# Altium schematic sheet sch1.SchDoc
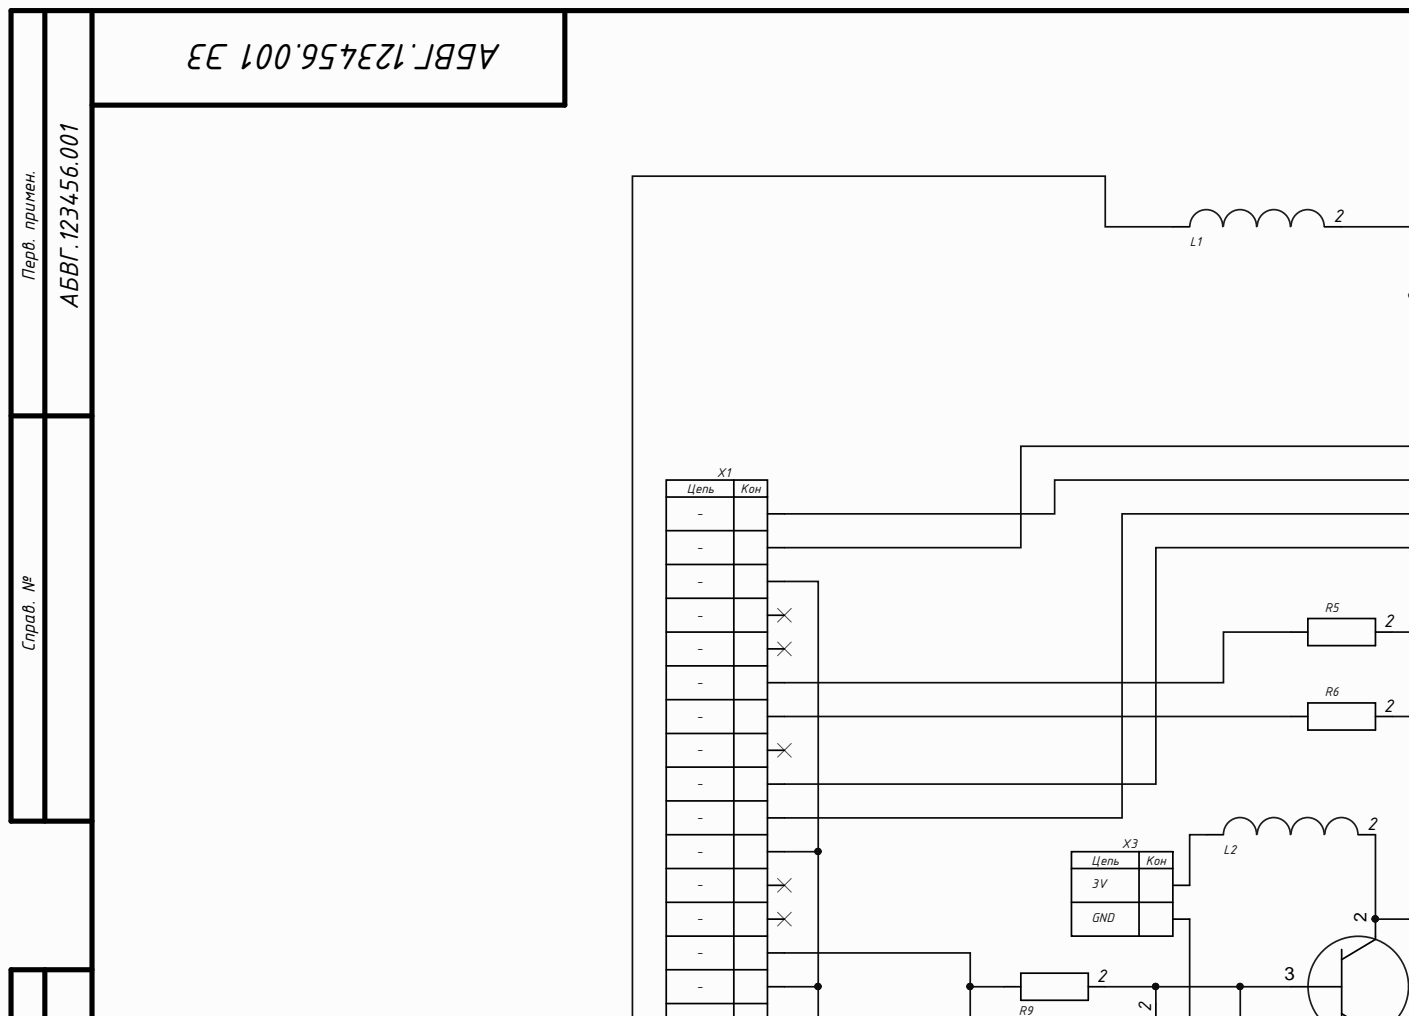
[diagram p0: sch1.SchDoc, top left]
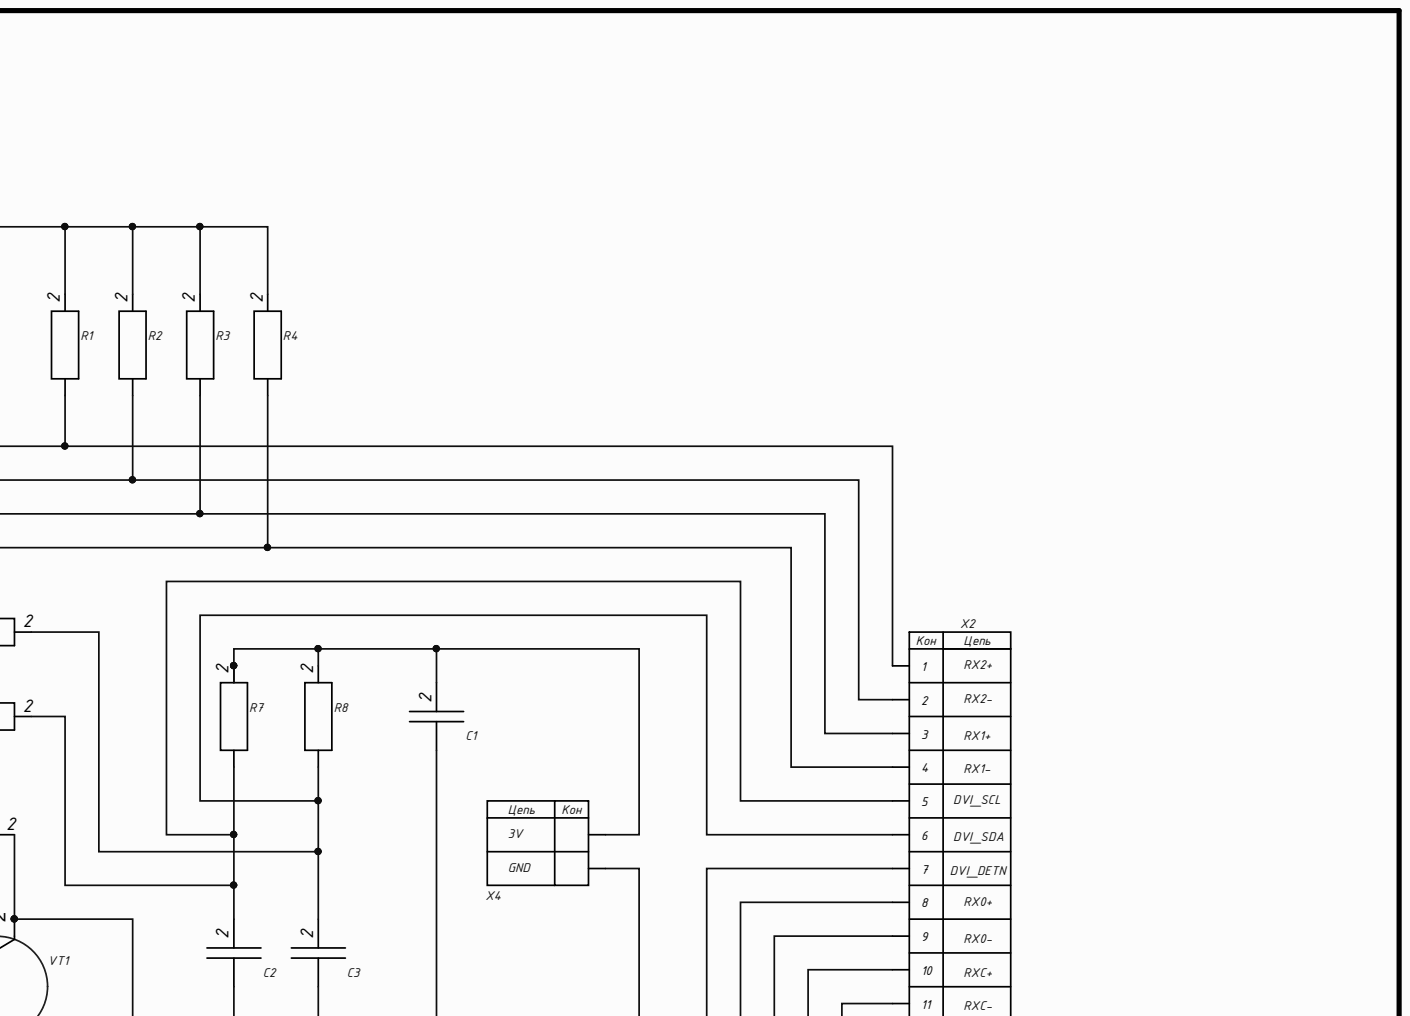
[diagram p1: sch1.SchDoc, top right]
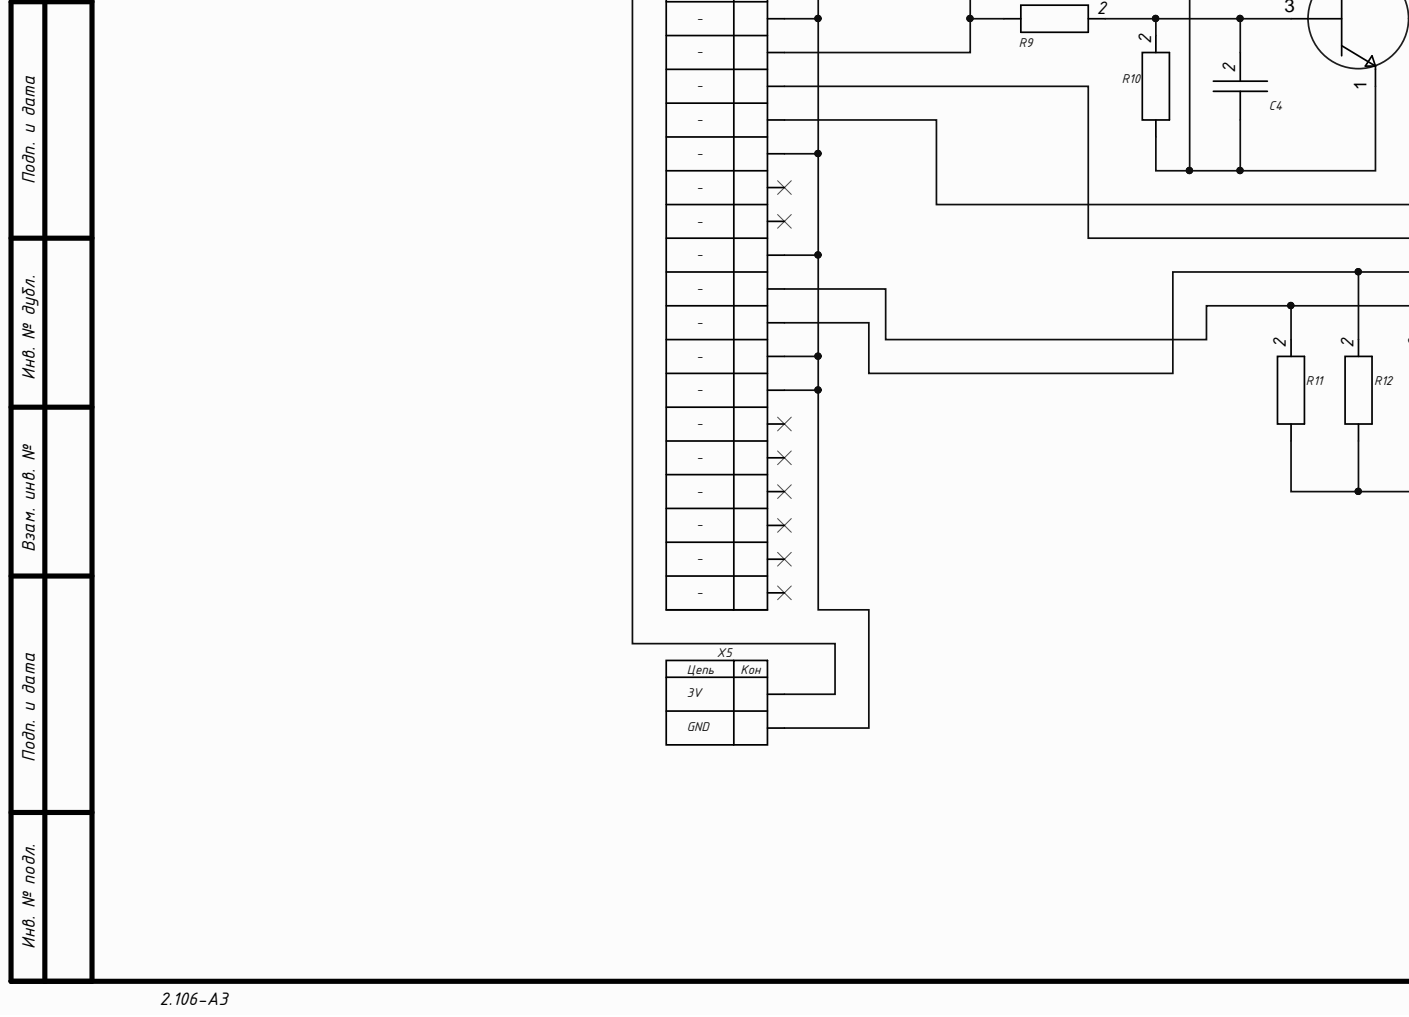
[diagram p2: sch1.SchDoc, bottom left]
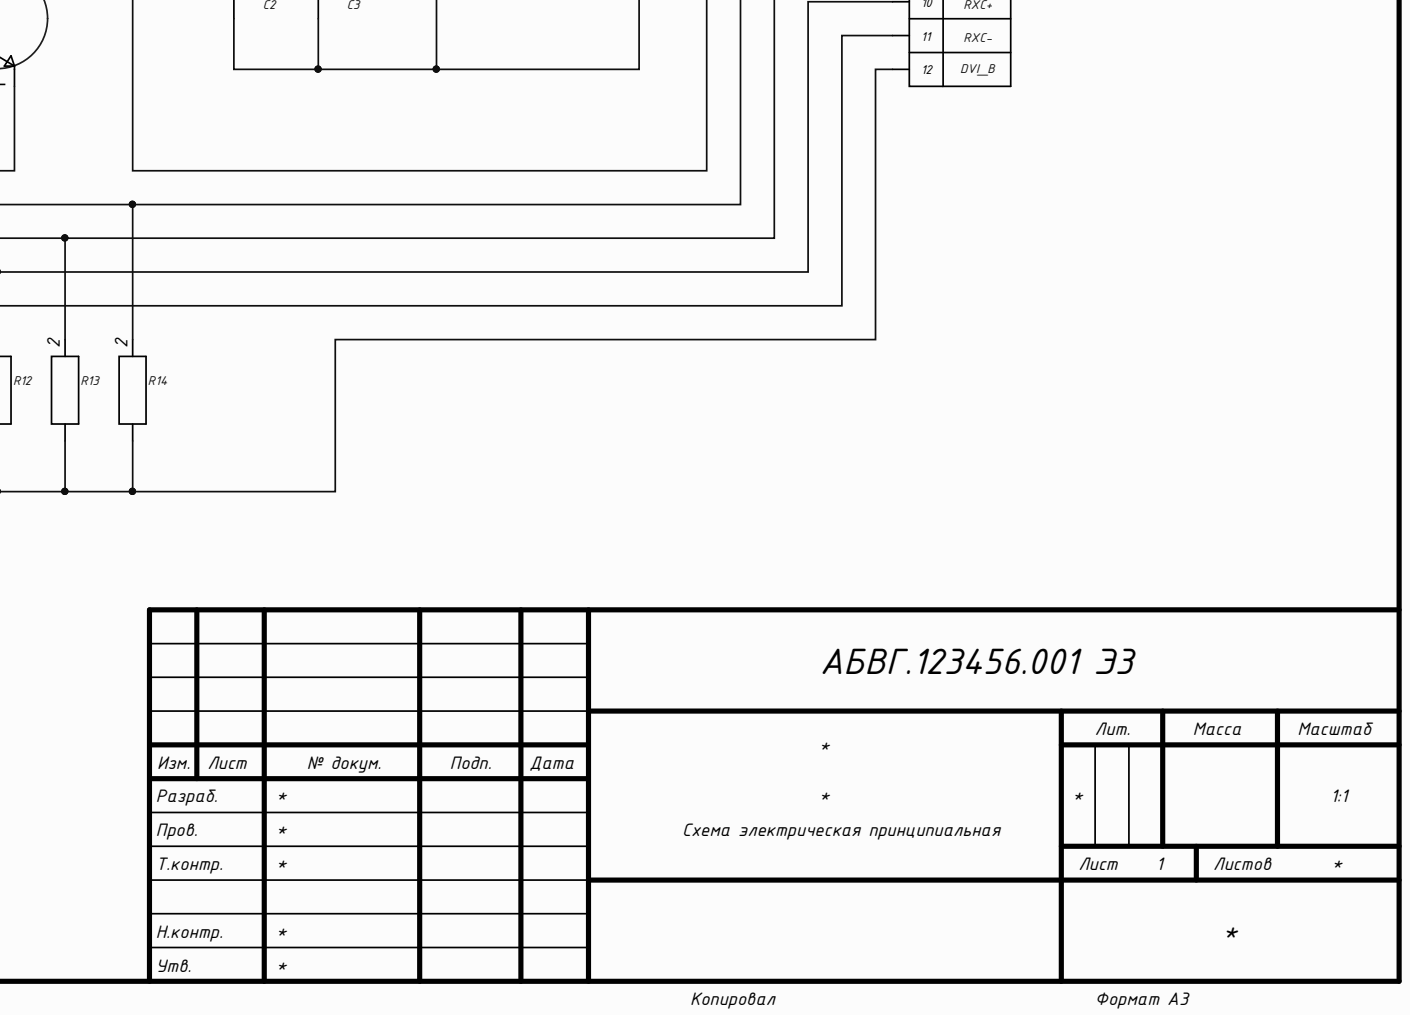
[diagram p3: sch1.SchDoc, bottom right]

## Parts
C1  (no value)  [Capacitor 0805]
C2  (no value)  [Capacitor 0805]
C3  (no value)  [Capacitor 0805]
C4  (no value)  [Capacitor 0805]
L1  (no value)  [Inductor 2520]
L2  (no value)  [Inductor 2520]
R1  (no value)  [Resistor 1206]
R2  (no value)  [Resistor 1206]
R3  (no value)  [Resistor 1206]
R4  (no value)  [Resistor 1206]
R5  (no value)  [Resistor 1206]
R6  (no value)  [Resistor 1206]
R7  (no value)  [Resistor 1206]
R8  (no value)  [Resistor 1206]
R9  (no value)  [Resistor 1206]
R10  (no value)  [Resistor 1206]
R11  (no value)  [Resistor 1206]
R12  (no value)  [Resistor 1206]
R13  (no value)  [Resistor 1206]
R14  (no value)  [Resistor 1206]
VT1  (no value)  [Transistor 1]
X1  (no value)  [DVI Connector]
X2  (no value)  [All pins]
X3  (no value)  [VCC-GND]
X4  (no value)  [VCC-GND]
X5  (no value)  [VCC-GND]

## Nets
NetC1_1: C1.1 C2.1 C3.1 X4.2
NetC1_2: C1.2 R7.2 R8.2 X4.1
NetC2_2: C2.2 R6.2 R7.1 X2.5
NetC3_2: C3.2 R5.2 R8.1 X2.6
NetC4_1: C4.1 R10.1 VT1.1 X3.2
NetC4_2: C4.2 R9.2 R10.2 VT1.3
NetL1_1: L1.1 X5.1
NetL1_2: L1.2 R1.2 R2.2 R3.2 R4.2
NetL2_1: L2.1 X3.1
NetL2_2: L2.2 VT1.2 X2.7
NetR1_1: R1.1 X1.2 X2.1
NetR2_1: R2.1 X1.1 X2.2
NetR3_1: R3.1 X1.10 X2.3
NetR4_1: R4.1 X1.9 X2.4
NetR5_1: R5.1 X1.6
NetR6_1: R6.1 X1.7
NetR9_1: R9.1 X1.14 X1.16
NetR11_1: R11.1 R12.1 R13.1 R14.1 X2.12
NetR11_2: R11.2 X1.23 X2.11
NetR12_2: R12.2 X1.24 X2.10
NetR13_2: R13.2 X1.17 X2.9
NetR14_2: R14.2 X1.18 X2.8
NetX1_3: X1.3 X1.11 X1.15 X1.19 X1.22 X1.25 X1.26 X5.2

Not connected: X1 4 5 8 12 13 20 21 27 28 29 30 31 32

## Library data of the parts
C1-C4 = Capacitor: Component Kind: Standard; Library Name: k7shared.IntLib
L1 L2 = Inductor: Component Kind: Standard; Library Name: k7shared.IntLib
R1-R14 = Resistor: Component Kind: Standard; Library Name: k7shared.IntLib
VT1 = Transistor 1: Component Kind: Standard; Library Name: k7shared.IntLib
X1 = DVI Connector: Component Kind: Standard; Library Name: k7sch1.IntLib
X2 = All pins: Component Kind: Standard; Library Name: k7sch1.IntLib
X3-X5 = VCC-GND R: Component Kind: Standard; Library Name: k7shared.IntLib
